# Altium schematic sheet ble_em8500.SchDoc
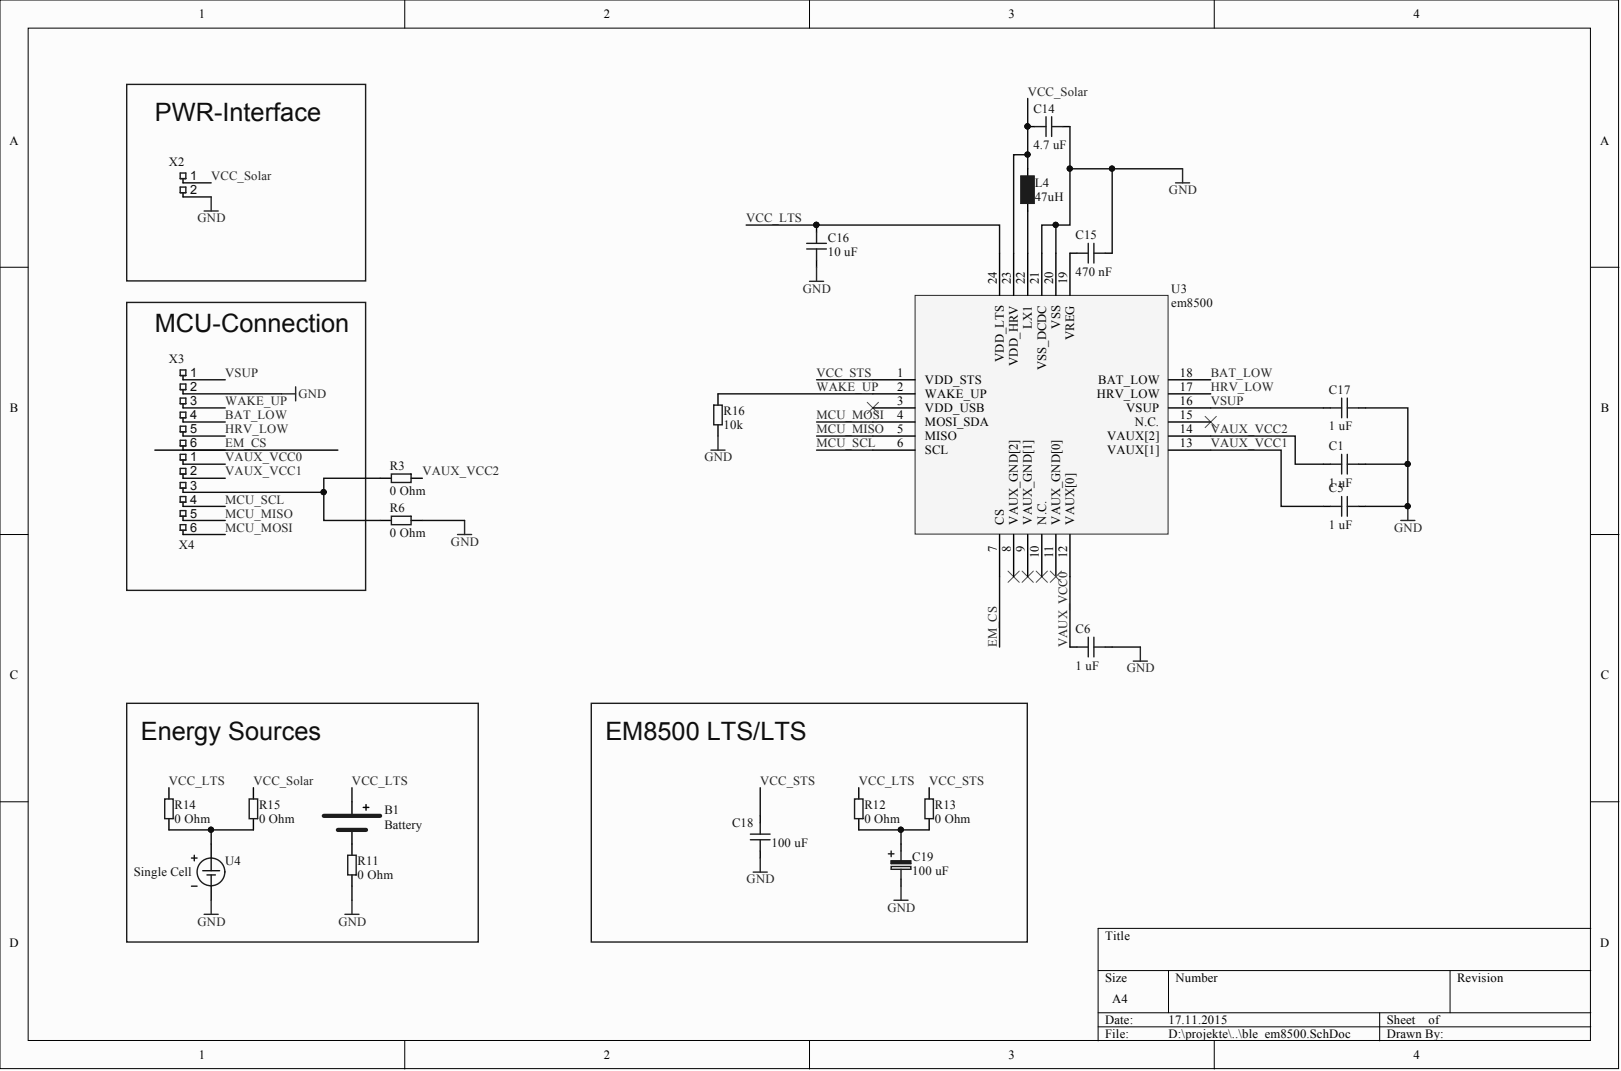
[diagram p0: ble_em8500.SchDoc]

## Parts
B1  Battery  [CR2032]
C1  value 1 uF  [Chip_1005L]  (hidden comment: Cap)
C5  value 1 uF  [Chip_1005L]  (hidden comment: Cap)
C6  value 1 uF  [Chip_1005L]  (hidden comment: Cap)
C14  value 4.7 uF  [Chip_2012L]  (hidden comment: Cap)
C15  value 470 nF  [Chip_2012L]  (hidden comment: Cap)
C16  value 10 uF  [Chip_2012L]  (hidden comment: Cap)
C17  value 1 uF  [Chip_1005L]  (hidden comment: Cap)
C18  value 100 uF  [Chip_3216L]  (hidden comment: Cap)
C19  value 100 uF  [TANT D]  (hidden comment: Cap pol)
L4  L  value 47uH  [LPS3008-103ML]
R3  R  value 0 Ohm  [Chip_0603L]
R6  R  value 0 Ohm  [Chip_0603L]
R11  R  value 0 Ohm  [Chip_0603L]
R12  R  value 0 Ohm  [Chip_0603L]
R13  R  value 0 Ohm  [Chip_0603L]
R14  R  value 0 Ohm  [Chip_0603L]
R15  R  value 0 Ohm  [Chip_0603L]
R16  R  value 10k  [Chip_0603L]
U3  em8500  [QFN-24]
U4  value Single Cell  [XOB17-12x1_SMALL]
X2  HEADER2  [HEADER 1x2 50mil]  (value not drawn)
X3  TEST  [HEADER 1x6 50mil]  (value not drawn)
X4  TEST  [HEADER 1x6 50mil]  (value not drawn)

## Nets
GND: C1.2 C5.2 C6.2 C14.2 C15.2 C16.2 C17.2 C18.1 C19.2 R6.1 R11.1 R16.2 U3.20(VSS) U3.21(VSS_DCDC) U4.2 X2.2 X3.2
BAT_LOW: U3.18(BAT_LOW) X3.4
EM_CS: U3.7(CS) X3.6
HRV_LOW: U3.17(HRV_LOW) X3.5
MCU_MISO: U3.5(MISO) X4.5
MCU_MOSI: U3.4(MOSI_SDA) X4.6
MCU_SCL: U3.6(SCL) X4.4
VAUX_VCC0: C6.1 U3.12(VAUX[0]) X4.1
VAUX_VCC1: C5.1 U3.13(VAUX[1]) X4.2
VAUX_VCC2: C1.1 R3.1 U3.14(VAUX[2])
VCC_LTS: B1.1 C16.1 R12.2 R14.2 U3.24(VDD_LTS)
VCC_SOLAR: C14.1 L4.2 R15.2 U3.23(VDD_HRV) X2.1
VCC_STS: C18.2 R13.2 U3.1(VDD_STS)
VSUP: C17.1 U3.16(VSUP) X3.1
WAKE_UP: R16.1 U3.2(WAKE_UP) X3.3
NetB1_2: B1.2 R11.2
NetC15_1: C15.1 U3.19(VREG)
NetC19_1: C19.1 R12.1 R13.1
NetL4_1: L4.1 U3.22(LX1)
NetR3_2: R3.2 R6.2 X4.3
NetR14_1: R14.1 R15.1 U4.1

Not connected: U3 3(VDD_USB) 8(VAUX_GND[2]) 9(VAUX_GND[1]) 10(N.C.) 11(VAUX_GND[0]) 15(N.C.)

## Library data of the parts
B1 = BAT: Battery Holder; Category: Battery Holder; Component Kind: Standard; Library Name: newlib.SchLib
C1 C5 C6 C14-C18 = C: Capacitor; Category: Capacitor; Component Kind: Standard; Library Name: newlib.SchLib
C19 = C POL: Capacitor polarized; Category: Capacitor; Component Kind: Standard; Library Name: newlib.SchLib
L4 = L: Ind; Manufacturer: Coilcraft; Manufacturer Part Number: LPS3008-473MLB; Category: Inductor; Component Kind: Standard; Supplier 1: Mouser; Supplier 1 Part Number: 994-LPS3008-473MLB; Supplier 2: Digi-Key; Supplier Part Number 2: 490-6631-2-ND; Library Name: newlib.IntLib
R3 R6 R11-R16 = R: Resistor; Category: Resistor; Component Kind: Standard; Library Name: newlib.SchLib
U4 = SOLARCELL: Single Solar Cell; Manufacturer: IXYS; Manufacturer Part Number: XOB17-12x1; Category: SolarCell; Component Kind: Standard; Custom Description: Single Solar Cell; Supplier 1: Distrelec; Supplier Part Number 1: 660071; Library Name: newlib.SchLib
X2 = CON 1x2: Connector 2 Pol; Category: Connector; Component Kind: Standard; Library Name: newlib.SchLib
X3 X4 = CON 1x6: Connector 6 Pol; Category: Connector; Component Kind: Standard; Library Name: newlib.SchLib
U3 = em8500: Component Kind: Standard; Library Name: Schlib1.SchLib
